# Altium schematic sheet Stage2C_5volt_reg.SchDoc
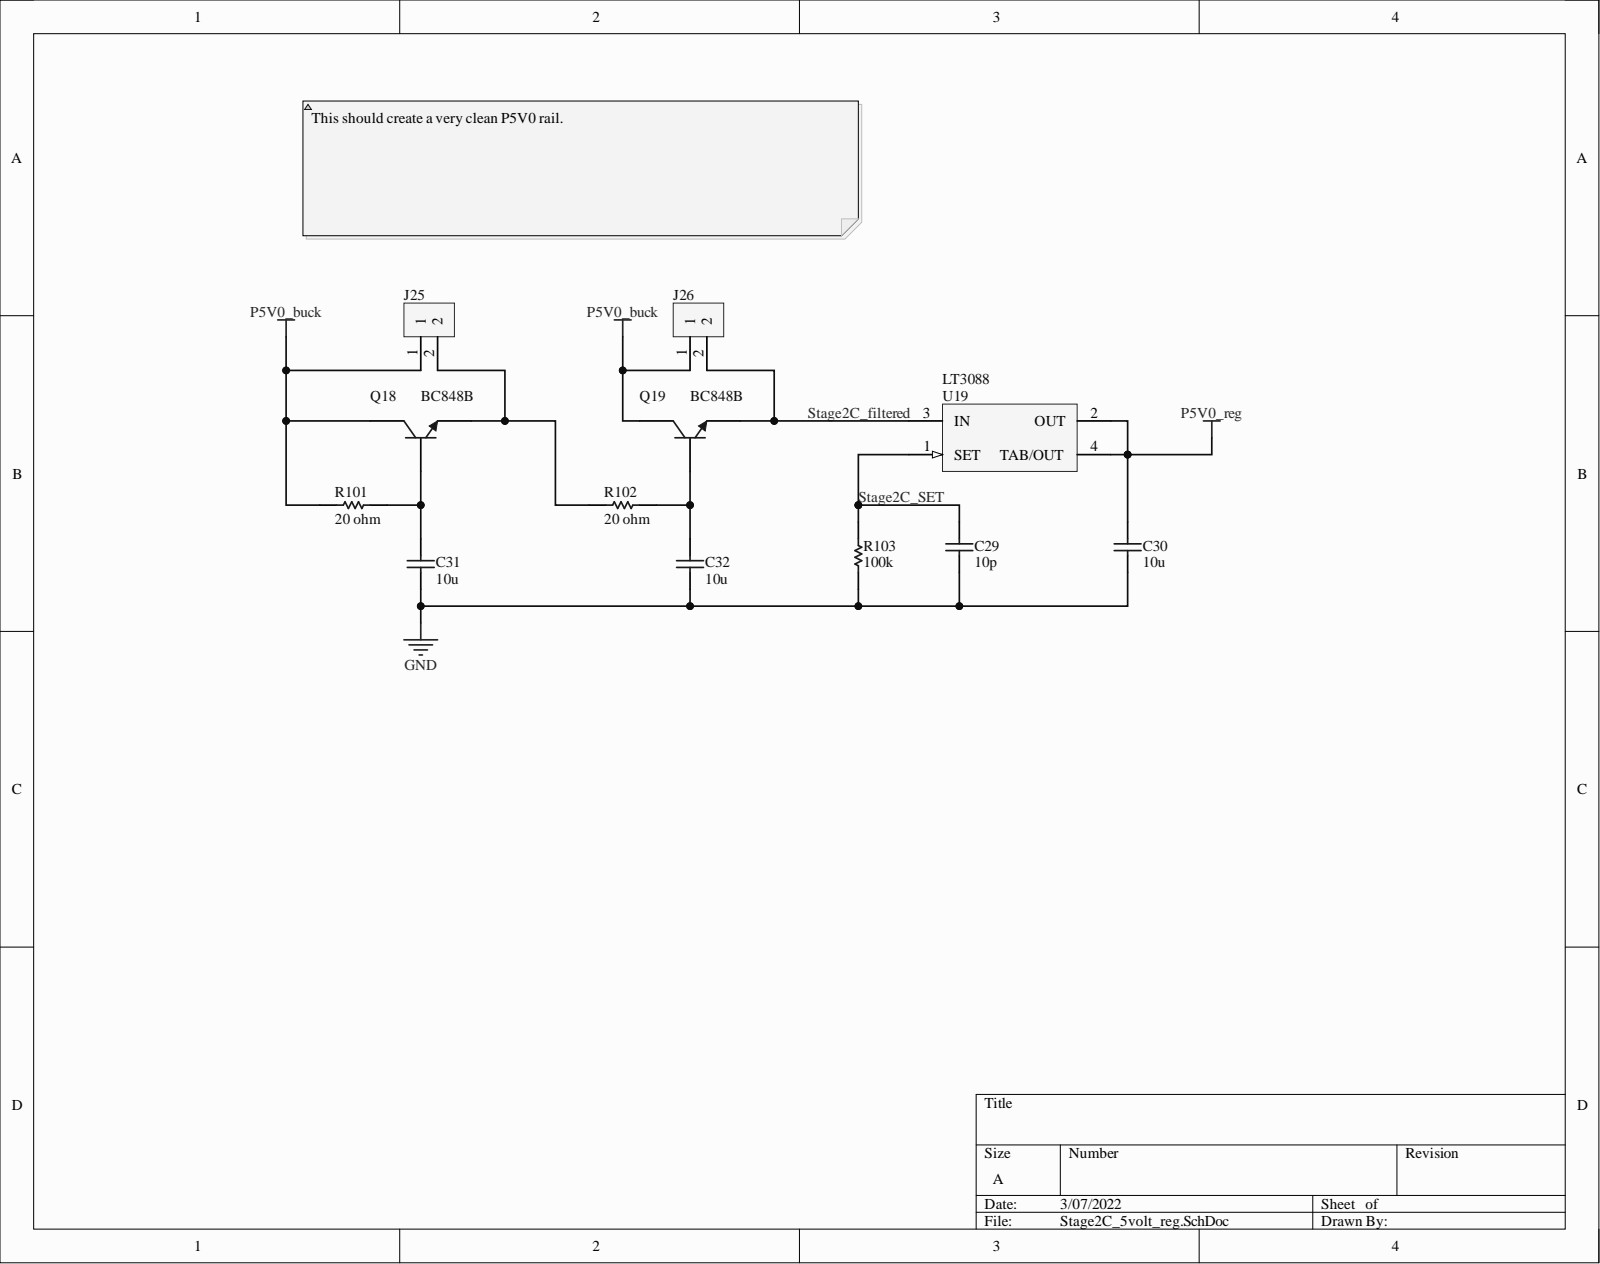
[diagram p0: Stage2C_5volt_reg.SchDoc]

## Parts
C29  10p  [CAPC2013X94X50NL20T25]
C30  10u  [PCB-l9n8ztvhhcto7vdn4z4q-1]
C31  10u  [PCB-l9n8ztvhhcto7vdn4z4q-1]
C32  10u  [PCB-l9n8ztvhhcto7vdn4z4q-1]
J25  (no value)  [PCB-omgsbkhp90n7lpri88og-1]
J26  (no value)  [PCB-omgsbkhp90n7lpri88og-1]
Q18  BC848B  [PCB-hbuntr9r1m1073lqpw4t-1]
Q19  BC848B  [PCB-hbuntr9r1m1073lqpw4t-1]
R101  20 ohm  [RESC2013X70X40ML20T20]
R102  20 ohm  [RESC2013X70X40ML20T20]
R103  100k  [PCB-p00ux61zw156yfuk4gsu-1]
U19  LT3088  [PCB-3oqfx817v31zl7v4z3es-1]

## Nets
GND: C29.1 C30.1 C31.1 C32.1 R103.1
P5V0_buck: J25.1 J26.1 Q18.1 Q19.1 R101.1
P5V0_reg: C30.2 U19.2(OUT) U19.4(TAB/OUT)
Stage2C_filtered: J26.2 Q19.3 U19.3(IN)
Stage2C_SET: C29.2 R103.2 U19.1(SET)
NetC31_2: C31.2 Q18.2 R101.2
NetC32_2: C32.2 Q19.2 R102.2
NetJ25_2: J25.2 Q18.3 R102.1

## Library data of the parts
C29 = CMP-128474-2: CAP CER 10PF 100V 5% NP0 0805; Manufacturer Part Number: 08051A100JAT2A; Capacitance: 1e-11 F; Case/Package (SI): 2012; Dielectric Characteristic: C0G/NP0; Dielectric Material: Ceramic Multilayer; Insulation Resistance: 1e+11 Ω; Lead-Free Status: Lead Free; Temperature Coefficient: 0.0 ppm/°C; Voltage Rating (DC): 100.0 V; Capacitance Tolerance: ±5%; Case/Package: 0805; Component Kind: Standard; Library Name: My Personal Space; Mounting Style: Surface Mount; Number of Pins: 2; Octopart Url: https://octopart.com/08051a100jat2a-avx+interconnect+%2F+elco-40099703; OctopartID: de1d1574240c01da; REACH SVHC Compliance: No SVHC; RoHS: Compliant; Size-Height: 0.00071 m; Size-Length: 0.0020066 m; Size-Thickness: 0.0009398 m; Size-Width: 0.00125 m
C30-C32 = CMP-16d6dd0bbcf4c911-3: Cap Ceramic 10uF 25V X6S 10% SMD 0805 105°C Embossed Plastic T/R; Manufacturer Part Number: CL21X106KAYNNNE; Capacitance: 10.0 µF; Lead-Free Status: Lead Free; Voltage Rating (DC): 25.0 V; Capacitance Tolerance: ±10%; Case/Package: 0805; Component Kind: Standard; Dielectric Characteristic: X6S; Library Name: My Personal Space; Octopart URL: https://octopart.com/cl21x106kaynnne-samsung-47681348; OctopartID: 16d6dd0bbcf4c911; RoHS: Compliant
J25 J26 = CMP-748fbcb73d6bab48-30: Pitch 2.54 mm, Single Row, 2pins; Component Kind: Standard; Library Name: My Personal Space
Q18 Q19 = CMP-64f7a94c3727bb30-1: BC848; Manufacturer Part Number: BC848B,215; Lead-Free Status: Lead Free; Polarity: NPN, N-Channel; Case/Package: SOT-23; Component Kind: Standard; Library Name: My Personal Space; Lifecycle Status: Active; Mounting Style: Surface Mount; Number of Pins: 3; Octopart URL: https://octopart.com/bc848b%2C215-nexperia-78739126; OctopartID: 64f7a94c3727bb30; Operating Temperature: -65.0 °C to 150 °C; Packaging: Cut Tape (CT), Tape & Reel (TR); REACH SVHC Compliance: No SVHC; RoHS: Compliant
R101 R102 = CMP-3653913-2: Thick Film Resistors - SMD 0805 20ohms 5% Tol; Manufacturer Part Number: ERJ-6GEYJ200V; Case/Package (SI): 2012; Lead-Free Status: Lead Free; Power Rating: 0.125 W; Resistance: 20.0 Ω; Voltage Rating (DC): 150.0 V; Case/Package: 0805; Component Kind: Standard; Library Name: My Personal Space; Lifecycle Status: Not Listed by Manufacturer; Mounting Style: Surface Mount; Octopart Url: https://octopart.com/erj-6geyj200v-panasonic-55422942; OctopartID: 2262e825a8dafda5; Packaging: Cut Tape (CT); REACH SVHC Compliance: No SVHC; Resistance Tolerance: ±5%; RoHS: Compliant; Size-Height: 0.0006 m; Size-Length: 0.002 m; Size-Width: 0.00125 m
R103 = CMP-1854318-20: Res Thick Film 0805 100K Ohm 1% 1/8W ±100ppm/°C Molded SMD Paper T/R; Manufacturer Part Number: RC0805FR-07100KL; Case/Package (SI): 2012; Lead-Free Status: Lead Free; Power Rating: 125 mW; Resistance: 100 kΩ; Temperature Coefficient: -100 ppm/°C to 100 ppm/°C; Voltage Rating (DC): 150 V; Case/Package: 0805; Component Kind: Standard; Library Name: My Personal Space; Mounting Style: Surface Mount; Number of Pins: 2; Octopart URL: https://octopart.com/rc0805fr-07100kl-yageo-40025548; OctopartID: f9d232ba61782615; Operating Temperature: 155 °C (max); Packaging: Tape & Reel; REACH SVHC Compliance: No SVHC; Resistance Tolerance: ±1%; RoHS: Compliant; Size-Height: 500 µm; Size-Length: 2.00 mm; Size-Width: 1.25 mm
U19 = CMP-83a54925b58a5c62-3: The LT3088 is an 800mA low dropout linear regulator designed for rugged industrial applications. A key feature of the...; Manufacturer Part Number: LT3088EST#PBF; Lead-Free Status: Lead Free; Output Voltage: 34.5 V (max), 0.00 V (min); Component Kind: Standard; Library Name: My Personal Space; Lifecycle Status: Active; Number of Pins: 3; Octopart URL: https://octopart.com/lt3088est%23pbf-linear+technology-59380553; OctopartID: 83a54925b58a5c62; Operating Temperature: -40.0 °C (min); Output Current: 800 mA; REACH SVHC Compliance: No SVHC; RoHS: Compliant
